# Altium schematic sheet PwrLMS.SchDoc
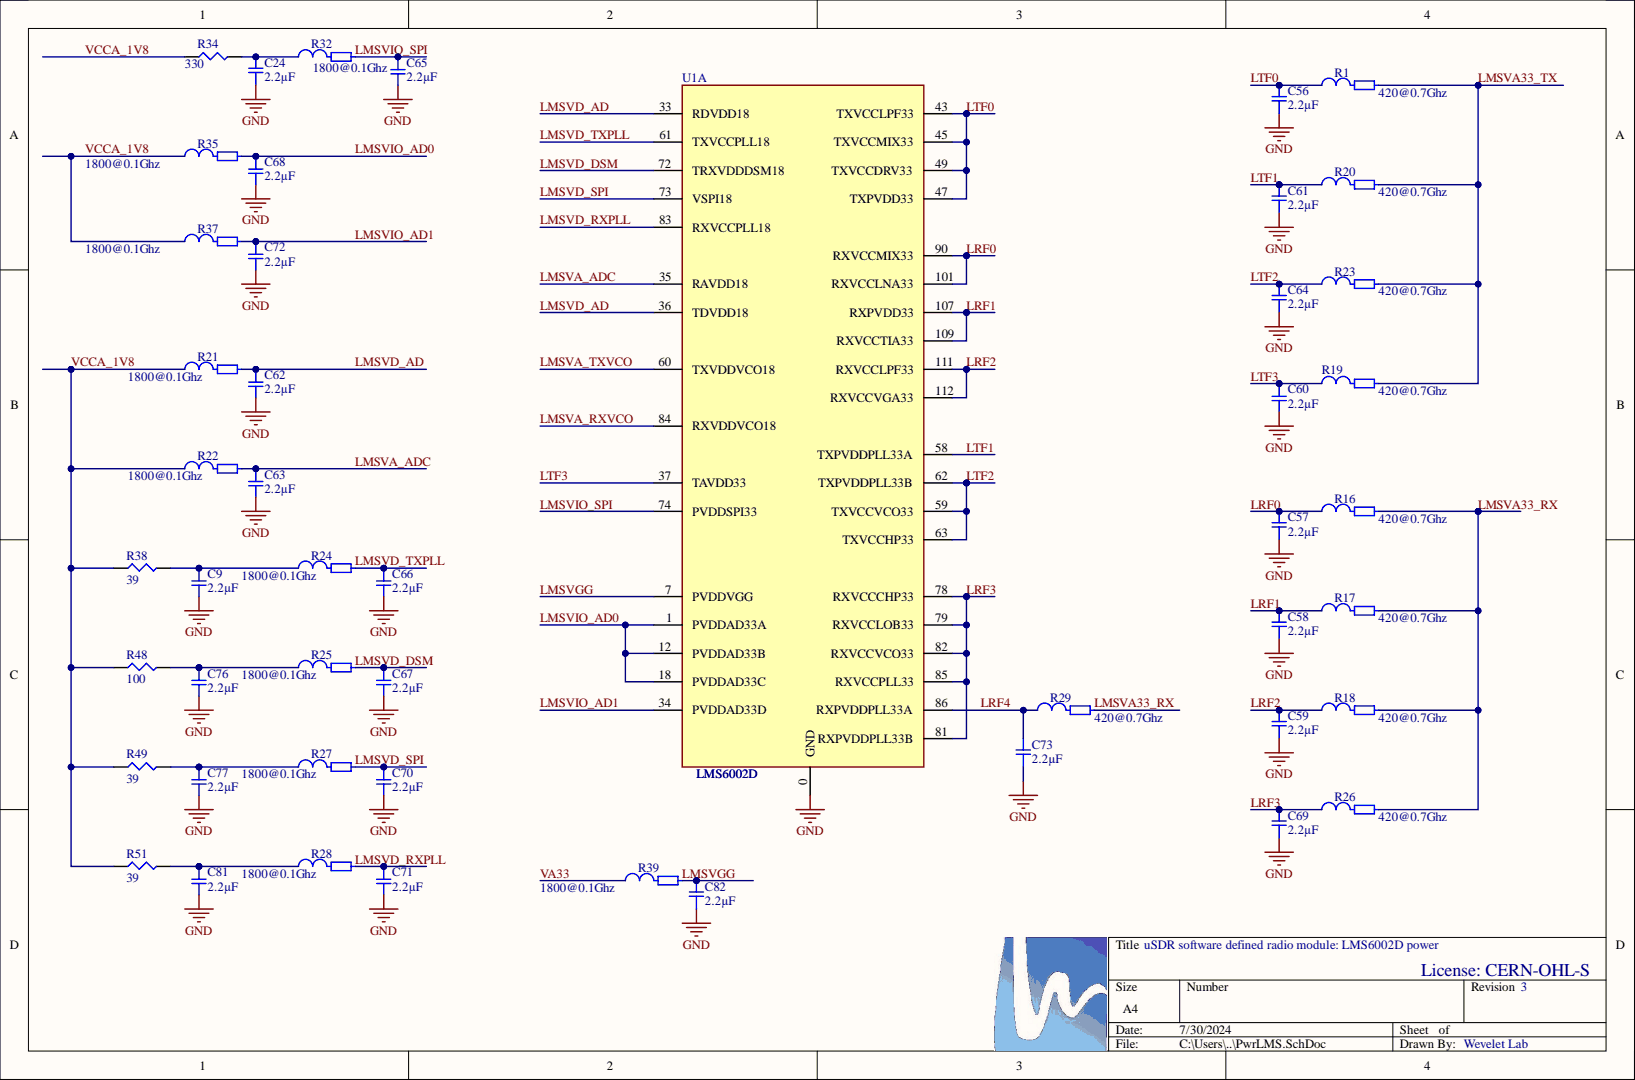
[diagram p0: PwrLMS.SchDoc]

## Parts
C9  value 2.2µF  [SMD-0201C]  (hidden comment: GRM033C80G225ME01D)
C24  value 2.2µF  [SMD-0201C]  (hidden comment: GRM033C80G225ME01D)
C56  value 2.2µF  [SMD-0201C]  (hidden comment: GRM033C80G225ME01D)
C57  value 2.2µF  [SMD-0201C]  (hidden comment: GRM033C80G225ME01D)
C58  value 2.2µF  [SMD-0201C]  (hidden comment: GRM033C80G225ME01D)
C59  value 2.2µF  [SMD-0201C]  (hidden comment: GRM033C80G225ME01D)
C60  value 2.2µF  [SMD-0201C]  (hidden comment: GRM033C80G225ME01D)
C61  value 2.2µF  [SMD-0201C]  (hidden comment: GRM033C80G225ME01D)
C62  value 2.2µF  [SMD-0201C]  (hidden comment: GRM033C80G225ME01D)
C63  value 2.2µF  [SMD-0201C]  (hidden comment: GRM033C80G225ME01D)
C64  value 2.2µF  [SMD-0201C]  (hidden comment: GRM033C80G225ME01D)
C65  value 2.2µF  [SMD-0201C]  (hidden comment: GRM033C80G225ME01D)
C66  value 2.2µF  [SMD-0201C]  (hidden comment: GRM033C80G225ME01D)
C67  value 2.2µF  [SMD-0201C]  (hidden comment: GRM033C80G225ME01D)
C68  value 2.2µF  [SMD-0201C]  (hidden comment: GRM033C80G225ME01D)
C69  value 2.2µF  [SMD-0201C]  (hidden comment: GRM033C80G225ME01D)
C70  value 2.2µF  [SMD-0201C]  (hidden comment: GRM033C80G225ME01D)
C71  value 2.2µF  [SMD-0201C]  (hidden comment: GRM033C80G225ME01D)
C72  value 2.2µF  [SMD-0201C]  (hidden comment: GRM033C80G225ME01D)
C73  value 2.2µF  [SMD-0201C]  (hidden comment: GRM033C80G225ME01D)
C76  value 2.2µF  [SMD-0201C]  (hidden comment: GRM033C80G225ME01D)
C77  value 2.2µF  [SMD-0201C]  (hidden comment: GRM033C80G225ME01D)
C81  value 2.2µF  [SMD-0201C]  (hidden comment: GRM033C80G225ME01D)
C82  value 2.2µF  [SMD-0201C]  (hidden comment: GRM033C80G225ME01D)
R1  value 420@0.7Ghz  [SMD-0201-RES]  (hidden comment: BLF03JD421GNE)
R16  value 420@0.7Ghz  [SMD-0201-RES]  (hidden comment: BLF03JD421GNE)
R17  value 420@0.7Ghz  [SMD-0201-RES]  (hidden comment: BLF03JD421GNE)
R18  value 420@0.7Ghz  [SMD-0201-RES]  (hidden comment: BLF03JD421GNE)
R19  value 420@0.7Ghz  [SMD-0201-RES]  (hidden comment: BLF03JD421GNE)
R20  value 420@0.7Ghz  [SMD-0201-RES]  (hidden comment: BLF03JD421GNE)
R21  value 1800@0.1Ghz  [SMD-0201-RES]  (hidden comment: BLM03HD182FN1)
R22  value 1800@0.1Ghz  [SMD-0201-RES]  (hidden comment: BLM03HD182FN1)
R23  value 420@0.7Ghz  [SMD-0201-RES]  (hidden comment: BLF03JD421GNE)
R24  value 1800@0.1Ghz  [SMD-0201-RES]  (hidden comment: BLM03HD182FN1)
R25  value 1800@0.1Ghz  [SMD-0201-RES]  (hidden comment: BLM03HD182FN1)
R26  value 420@0.7Ghz  [SMD-0201-RES]  (hidden comment: BLF03JD421GNE)
R27  value 1800@0.1Ghz  [SMD-0201-RES]  (hidden comment: BLM03HD182FN1)
R28  value 1800@0.1Ghz  [SMD-0201-RES]  (hidden comment: BLM03HD182FN1)
R29  value 420@0.7Ghz  [SMD-0201-RES]  (hidden comment: BLF03JD421GNE)
R32  value 1800@0.1Ghz  [SMD-0201-RES]  (hidden comment: BLM03HD182FN1)
R34  330  [SMD-0201-RES]
R35  value 1800@0.1Ghz  [SMD-0201-RES]  (hidden comment: BLM03HD182FN1)
R37  value 1800@0.1Ghz  [SMD-0201-RES]  (hidden comment: BLM03HD182FN1)
R38  39  [SMD-0201-RES]
R39  value 1800@0.1Ghz  [SMD-0201-RES]  (hidden comment: BLM03HD182FN1)
R48  100  [SMD-0201-RES]
R49  39  [SMD-0201-RES]
R51  39  [SMD-0201-RES]
U1  LMS6002D  [DQFN120(9x9/0.5mm)]  (part A)

## Nets
GND: C9.2 C24.1 C56.1 C57.1 C58.1 C59.1 C60.2 C61.1 C62.2 C63.2 C64.1 C65.2 C66.2 C67.2 C68.2 C69.1 C70.2 C71.2 C72.2 C73.1 C76.2 C77.2 C81.2 C82.1 U1.0(GND)
LMSVA33_RX: R16.2 R17.2 R18.2 R26.2 R29.2
LMSVA33_TX: R1.2 R19.2 R20.2 R23.2
LMSVA_ADC: C63.1 R22.2 U1.35(RAVDD18)
LMSVA_RXVCO: U1.84(RXVDDVCO18)
LMSVA_TXVCO: U1.60(TXVDDVCO18)
LMSVD_AD: C62.1 R21.2 U1.33(RDVDD18) U1.36(TDVDD18)
LMSVD_DSM: C67.1 R25.2 U1.72(TRXVDDDSM18)
LMSVD_RXPLL: C71.1 R28.2 U1.83(RXVCCPLL18)
LMSVD_SPI: C70.1 R27.2 U1.73(VSPI18)
LMSVD_TXPLL: C66.1 R24.2 U1.61(TXVCCPLL18)
LMSVGG: C82.2 R39.2 U1.7(PVDDVGG)
LMSVIO_AD0: C68.1 R35.2 U1.1(PVDDAD33A) U1.12(PVDDAD33B) U1.18(PVDDAD33C)
LMSVIO_AD1: C72.1 R37.2 U1.34(PVDDAD33D)
LMSVIO_SPI: C65.1 R32.2 U1.74(PVDDSPI33)
LRF0: C57.2 R16.1 U1.90(RXVCCMIX33) U1.101(RXVCCLNA33)
LRF1: C58.2 R17.1 U1.107(RXPVDD33) U1.109(RXVCCTIA33)
LRF2: C59.2 R18.1 U1.111(RXVCCLPF33) U1.112(RXVCCVGA33)
LRF3: C69.2 R26.1 U1.78(RXVCCCHP33) U1.79(RXVCCLOB33) U1.81(RXPVDDPLL33B) U1.82(RXVCCVCO33) U1.85(RXVCCPLL33)
LRF4: C73.2 R29.1 U1.86(RXPVDDPLL33A)
LTF0: C56.2 R1.1 U1.43(TXVCCLPF33) U1.45(TXVCCMIX33) U1.47(TXPVDD33) U1.49(TXVCCDRV33)
LTF1: C61.2 R20.1 U1.58(TXPVDDPLL33A)
LTF2: C64.2 R23.1 U1.59(TXVCCVCO33) U1.62(TXPVDDPLL33B) U1.63(TXVCCHP33)
LTF3: C60.1 R19.1 U1.37(TAVDD33)
VA33: R39.1
VCCA_1V8: R21.1 R22.1 R34.1 R35.1 R37.1 R38.1 R48.1 R49.1 R51.1
NetC9_1: C9.1 R24.1 R38.2
NetC24_2: C24.2 R32.1 R34.2
NetC76_1: C76.1 R25.1 R48.2
NetC77_1: C77.1 R27.1 R49.2
NetC81_1: C81.1 R28.1 R51.2

## Library data of the parts
C9 C24 C56-C73 C76 C77 C81 C82 = GRM033C80G225ME01D: CAP CER 2.2UF 4V X6S 0201; Manufacturer: Murata Electronics North America; @ qty: 0; Minimum Quantity: 1; Tolerance: ±20%; Unit Price (USD): 0.54; Voltage: 6.3V; Applications: General Purpose; Component Kind: Standard; Datasheets: http://psearch.en.murata.com/capacitor/product/GRM035R60J475ME15%23.pdf; Digi-Key Part Number: 490-GRM033C80G225ME01DTR-ND; Factory Stock: 0; Failure Rate: Surface Mount, MLCC; Features: Derating Recommended; Height - Seated (Max): 0.022" (0.55mm); Image: //media.digikey.com/Renders/Murata%20Renders/ZRB-SERIES-0402.jpg; Lead Spacing: -; Mounting Type: 0201 (0603 Metric); Operating Temperature: -55°C ~105°C; Package / Case: 0.024" L x 0.012" W (0.60mm x 0.30mm); Packaging: Cut Tape (CT); Part Number: GRM033C80G225ME01D; Part Status: Active; Quantity Available: 67188; Ratings: -; Series: GRM; Size / Dimension: -; Temperature Coefficient: X6S; Thickness (Max): -; Library Name: USDR.IntLib
R1 R16-R20 R23 R26 R29 = BLF03JD421GNE: BEAD 0.28Ohm/480mA 420@0.7Ghz; Manufacturer: TDKMurata Electronics North America; Tolerance: ±40%; Component Kind: Standard; Link: https://product.tdk.com/system/files/dam/doc/product/inductor/inductor/smd/catalog/inductor_commercial_high-frequency_mlg0603p_en.pdf; Name: BLF03JD421GNE; Library Name: USDR.IntLib
R21 R22 R24 R25 R27 R28 R32 R35 R37 R39 = BLM03HD182FN1: BEAD 3.8Ohm/100mA 1800@0.1Ghz/3000@1.0Ghz; Manufacturer: TDKMurata Electronics North America; Tolerance: ±3%; Component Kind: Standard; Link: https://product.tdk.com/system/files/dam/doc/product/inductor/inductor/smd/catalog/inductor_commercial_high-frequency_mlg0603p_en.pdf; Name: BLM03HD182FN1; Library Name: USDR.IntLib
R34 = ERJ-1GEJ331C: RES SMD 330 OHM 5% 1/20W 0201; Manufacturer: Panasonic Electronic Components; @ qty: 1500; Factory Stock: 0; Minimum Quantity: 1; Power (Watts): 0.05W, 1/20W; Temperature Coefficient: ±200ppm/°C; Unit Price (USD): 0.00787; Component Kind: Standard; Composition: Thick Film; Datasheets: //media.digikey.com/pdf/Data%20Sheets/Panasonic%20Resistors%20Thermistors%20PDFs/ERJ%205%25.pdf; Digi-Key Part Number: P100AGCT-ND; Failure Rate: -; Features: -; Height - Seated (Max): 0.010" (0.26mm); Image: //media.digikey.com/Renders/Panasonic%20Renders/ERJ-0201%20Pkg.jpg; Number of Terminations: 2; Operating Temperature: -55°C ~ 125°C; Package / Case: 0201 (0603 Metric); Packaging: Cut Tape (CT); Part Number: ERJ-1GEJ331C; Part Status: Active; Quantity Available: 115724; Series: ERJ; Size / Dimension: 0.024" L x 0.012" W (0.60mm x 0.30mm); Supplier Device Package: 0201; Tolerance: ±5%; Value: 330; Library Name: USDR.IntLib
R38 R49 R51 = ERJ-1GEJ390C: RES SMD 39 OHM 5% 1/20W 0201; Manufacturer: Panasonic Electronic Components; @ qty: 1500; Factory Stock: 0; Minimum Quantity: 1; Power (Watts): 0.05W, 1/20W; Temperature Coefficient: ±200ppm/°C; Unit Price (USD): 0.00787; Component Kind: Standard; Composition: Thick Film; Datasheets: //media.digikey.com/pdf/Data%20Sheets/Panasonic%20Resistors%20Thermistors%20PDFs/ERJ%205%25.pdf; Digi-Key Part Number: P100AGCT-ND; Failure Rate: -; Features: -; Height - Seated (Max): 0.010" (0.26mm); Image: //media.digikey.com/Renders/Panasonic%20Renders/ERJ-0201%20Pkg.jpg; Number of Terminations: 2; Operating Temperature: -55°C ~ 125°C; Package / Case: 0201 (0603 Metric); Packaging: Cut Tape (CT); Part Number: ERJ-1GEJ390C; Part Status: Active; Quantity Available: 115724; Series: ERJ; Size / Dimension: 0.024" L x 0.012" W (0.60mm x 0.30mm); Supplier Device Package: 0201; Tolerance: ±5%; Value: 39; Library Name: USDR.IntLib
R48 = ERJ-1GEJ101C: RES SMD 100 OHM 5% 1/20W 0201; Manufacturer: Panasonic Electronic Components; @ qty: 1500; Factory Stock: 0; Minimum Quantity: 1; Power (Watts): 0.05W, 1/20W; Temperature Coefficient: ±200ppm/°C; Unit Price (USD): 0.00787; Component Kind: Standard; Composition: Thick Film; Datasheets: //media.digikey.com/pdf/Data%20Sheets/Panasonic%20Resistors%20Thermistors%20PDFs/ERJ%205%25.pdf; Digi-Key Part Number: P100AGCT-ND; Failure Rate: -; Features: -; Height - Seated (Max): 0.010" (0.26mm); Image: //media.digikey.com/Renders/Panasonic%20Renders/ERJ-0201%20Pkg.jpg; Number of Terminations: 2; Operating Temperature: -55°C ~ 125°C; Package / Case: 0201 (0603 Metric); Packaging: Cut Tape (CT); Part Number: ERJ-1GEJ101C; Part Status: Active; Quantity Available: 115724; Series: ERJ; Size / Dimension: 0.024" L x 0.012" W (0.60mm x 0.30mm); Supplier Device Package: 0201; Tolerance: ±5%; Value: 100; Library Name: USDR.IntLib
U1 = LMS6002D: IC; Manufacturer: Lime Microsystems; Misc: Multi-band Multi-standard  Transceiver with Digital Interface; Component Kind: Standard; Name: LMS6002D; Library Name: USDR.IntLib
